# Altium schematic sheet Power.SchDoc
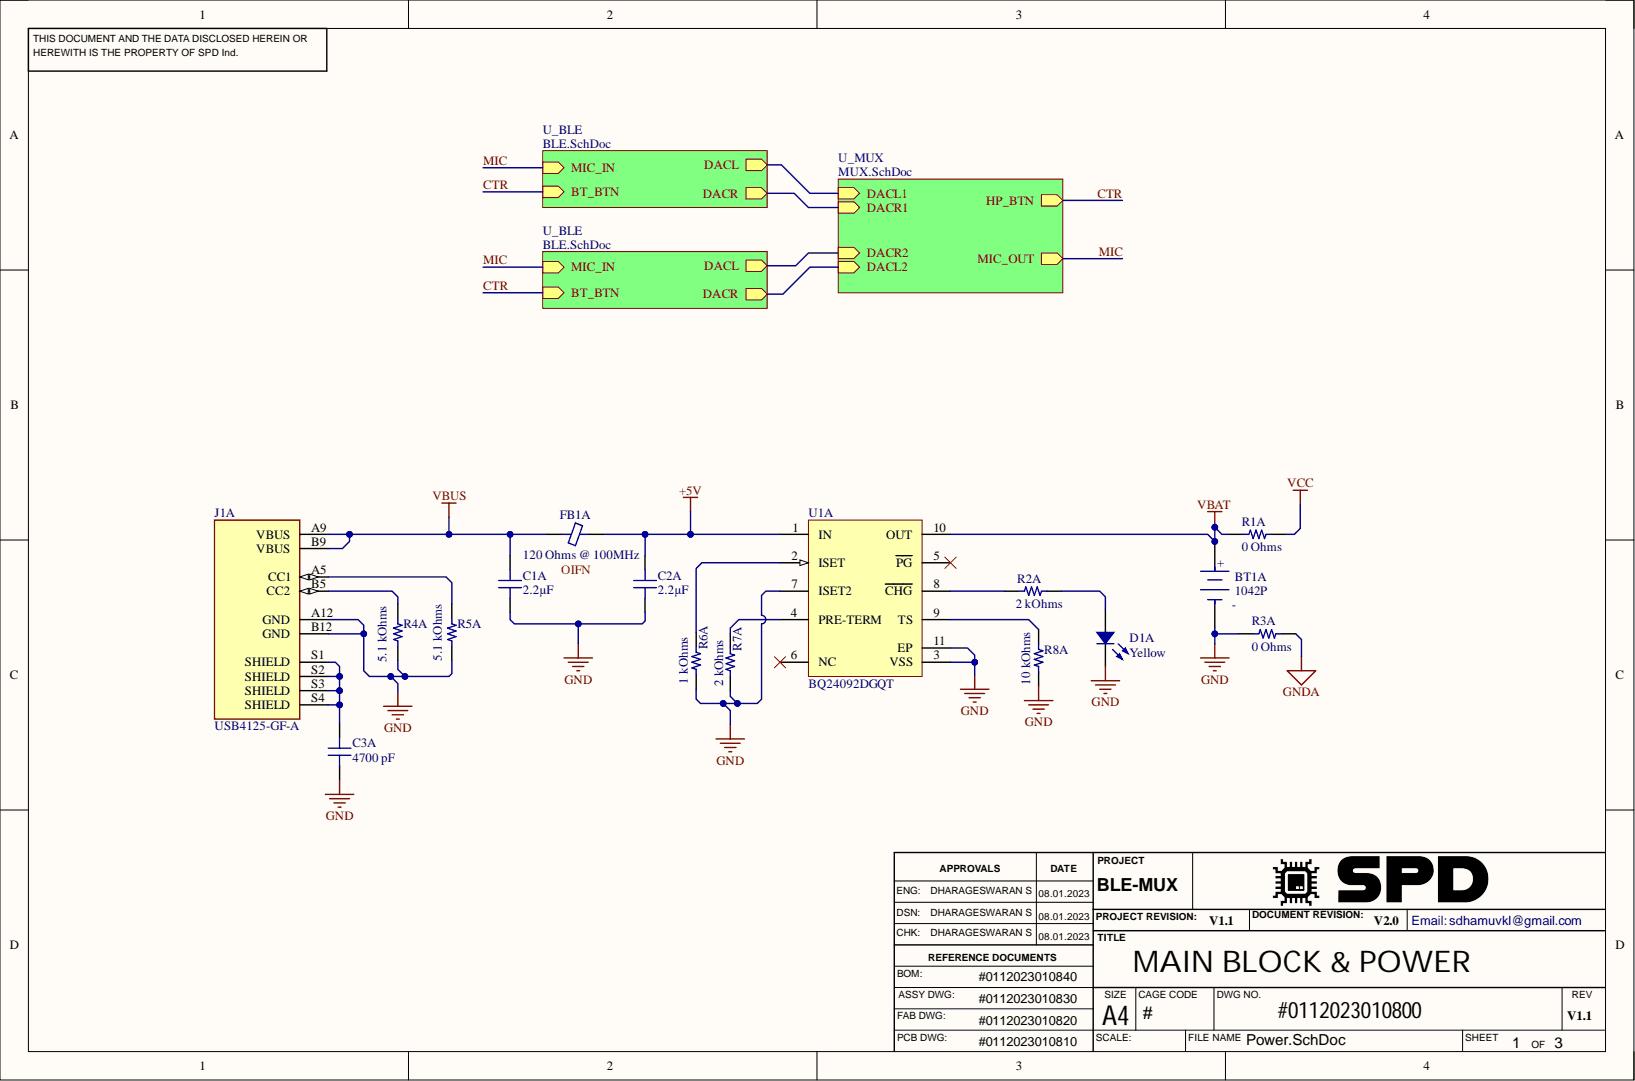
[diagram p0: Power.SchDoc]

## Parts
BT1A  1042P  [FP-1042P-MFG]
C1A  2.2µF  [CAP 0402_1005]
C2A  2.2µF  [CAP 0402_1005]
C3A  4700 pF  [CAP 0402_1005]
D1A  Yellow  [LED 0402_1005 YELLOW]
FB1A  120 Ohms @ 100MHz  [FER 0201_0603]
J1A  USB4125-GF-A  [USB4125-GF-A]
R1A  0 Ohms  [RES 0603_1608]
R2A  2 kOhms  [RES 0402_1005]
R3A  0 Ohms  [RES 0603_1608]
R4A  5.1 kOhms  [RES 0402_1005]
R5A  5.1 kOhms  [RES 0402_1005]
R6A  1 kOhms  [RES 0402_1005]
R7A  2 kOhms  [RES 0402_1005]
R8A  10 kOhms  [RES 0402_1005]
U1A  BQ24092DGQT  [DGQ0010D_L]

## Nets
+5V: C2A.2 FB1A.2 U1A.1(IN)
GND: BT1A.1 C1A.1 C2A.1 C3A.1 D1A.2 J1A.A12(GND) J1A.B12(GND) R3A.1 R4A.1 R5A.1 R6A.1 R7A.1 R8A.1 U1A.3(VSS) U1A.7(ISET2) U1A.11(EP)
GNDA: R3A.2
VBAT: BT1A.2 R1A.1 U1A.10(OUT)
VBUS: C1A.2 FB1A.1 J1A.A9(VBUS) J1A.B9(VBUS)
VCC: R1A.2
NetC3A_2: C3A.2 J1A.S1(SHIELD) J1A.S2(SHIELD) J1A.S3(SHIELD) J1A.S4(SHIELD)
NetD1A_1: D1A.1 R2A.1
NetJ1A_A5: J1A.A5(CC1) R5A.2
NetJ1A_B5: J1A.B5(CC2) R4A.2
NetR2A_2: R2A.2 U1A.8(CHG)
NetR6A_2: R6A.2 U1A.2(ISET)
NetR7A_2: R7A.2 U1A.4(PRE-TERM)
NetR8A_2: R8A.2 U1A.9(TS)
NetU1A_5: U1A.5(PG)
NetU1A_6: U1A.6(NC)
CTR:
DACL:
DACL2:
DACR:
MIC:

## Library data of the parts
BT1A = CMP-19636-000019-1: BATTERY HOLDER 18650 SMD TAB; Number of Cells: 1; Component Kind: Standard; Lead Free: Lead Free; Library Name: Altium Content Vault; Max Operating Temperature: 145°C; Min Operating Temperature: -50°C; Mount: Surface Mount; Package Quantity: 200; Packaging: Tray; Termination: SMD/SMT
C1A C2A = 0402ZD225KAT2A: CAP CER 2.2UF 10V X5R 0402; Manufacturer: AVX Corporation; Database Table Name: altium.Capacitors-Ceramic-0402; id: 2335; Tolerance: ±10%; Applications: General Purpose; Capacitance: 2.2µF; Component Kind: Standard; ComponentLink1Description: Datasheet; ComponentLink1URL: http://datasheets.avx.com/cx5r.pdf; ComponentLink2Description: DigiKey Link; ComponentLink2URL: http://digikey.com/product-detail/en/avx-corporation/0402ZD225KAT2A/478-10072-1-ND/6564294; Device Package: 0402 (1005 Metric); LastUpdated: 2022-02-08T12:26:45.707; Lifecycle Status: Active; Minimum Order: 1; Mounting Type: Surface Mount, MLCC; Operating Temperature: -55°C ~ 85°C; Package / Case: 0402 (1005 Metric); Packaging: TapeAndReel; Part Number: 0402ZD225KAT2A; Part Status: Active; PartId: 9346; Price: 0.09; Series: -; Size / Dimension: 0.039" L x 0.020" W (1.00mm x 0.50mm); Supplier 1: DigiKey; Supplier Part Number 1: 478-10072-1-ND; Temperature Coefficient: X5R; Thickness (Max): 0.022" (0.56mm); Value: 2.2µF; Voltage - Rated: 10V; Library Name: Postgres Altium Library - altium_library.DbLib
C3A = C0402C472K4RECAUTO: CAP CER 0402 4.7NF 16V X7R 10%; Manufacturer: KEMET; Database Table Name: altium.Capacitors-Ceramic-0402; id: 3032; Applications: Automotive, ESD Protection; Capacitance: 4700 pF; Component Kind: Standard; ComponentLink1Description: Datasheet; ComponentLink1URL: https://api.kemet.com/component-edge/download/datasheet/C0402C472K4RECAUTO.pdf; ComponentLink2Description: DigiKey Link; ComponentLink2URL: https://www.digikey.com/en/products/detail/kemet/C0402C472K4RECAUTO/8640469; Device Package: 0402 (1005 Metric); LastUpdated: 2023-01-03T20:03:46.940; Lifecycle Status: Active; Minimum Order: 1; Mounting Type: Surface Mount, MLCC; Operating Temperature: -55°C ~ 125°C; Package / Case: 0402 (1005 Metric); Packaging: TapeAndReel; Part Number: C0402C472K4RECAUTO; Part Status: Active; PartId: 12122; Price: 0.01; Ratings: AEC-Q200; Series: ESD SMD Auto X7R; Size / Dimension: 0.039" L x 0.020" W (1.00mm x 0.50mm); Supplier 1: DigiKey; Supplier Part Number 1: 399-17875-1-ND; Temperature Coefficient: X7R; Thickness (Max): 0.022" (0.55mm); Tolerance: ±10%; Value: 4700 pF; Voltage - Rated: 16V; Library Name: Postgres Altium Library - altium_library.DbLib
D1A = XZMYK68W-2: LED YELLOW CLEAR CHIP SMD; Manufacturer: SunLED; Configuration: Standard; Current - Test: 20mA; Database Table Name: altium.LED-Indication; id: 167; Lens Color: Colorless; Lens Size: 0.80mm x 0.50mm; Lens Style: Rectangle with Flat Top; Millicandela Rating: 148mcd; Viewing Angle: 120°; Voltage - Forward (Vf) (Typ): 2V; Wavelength - Dominant: 590nm; Color: Yellow; Component Kind: Standard; ComponentLink1Description: Datasheet; ComponentLink1URL: https://www.sunledusa.com/products/spec/XZMYK68W-2.pdf; ComponentLink2Description: DigiKey Link; ComponentLink2URL: https://www.digikey.com/en/products/detail/sunled/XZMYK68W-2/4745801; Device Package: 0402 (1005 Metric); Height (Max): 0.60mm; LastUpdated: 2023-01-02T20:57:30.307; Lens Transparency: Clear; Lifecycle Status: Active; Minimum Order: 1; Mounting Type: Surface Mount; Package / Case: 0402 (1005 Metric); Packaging: TapeAndReel; Part Number: XZMYK68W-2; Part Status: Active; PartId: 167; Price: 0.05; Series: -; Size / Dimension: 1.00mm L x 0.50mm W; Supplier 1: DigiKey; Supplier Device Package: Chip LED; Supplier Part Number 1: 1497-1198-1-ND; Value: Yellow; Wavelength - Peak: 590nm; Library Name: Postgres Altium Library - altium_library.DbLib
FB1A = BKP0603HM121-T: FERRITE BEAD 120 OHM 0201 1LN; Manufacturer: Taiyo Yuden; Current Rating (Max): 800mA; Database Table Name: altium.Filters-FerriteBeadsAndChips; DC Resistance (DCR) (Max): 180mOhm; Filter Type: Power Line; id: 127; Number Of Lines: 1; Component Kind: Standard; ComponentLink1Description: Datasheet; ComponentLink1URL: https://ds.yuden.co.jp/TYCOMPAS/ut/detail?pn=BKP0603HM121-T  &u=M; ComponentLink2Description: DigiKey Link; ComponentLink2URL: http://digikey.com/product-detail/en/taiyo-yuden/BKP0603HM121-T/587-3283-1-ND/4157927; Device Package: 0201 (0603 Metric); Height (Max): 0.013" (0.33mm); Impedance @ Frequency: 120 Ohms @ 100MHz; LastUpdated: 2023-01-02T20:50:51.660; Lifecycle Status: Active; Minimum Order: 1; Mounting Type: Surface Mount; Operating Temperature: -55°C ~ 85°C; Package / Case: 0201 (0603 Metric); Packaging: TapeAndReel; Part Number: BKP0603HM121-T; Part Status: Active; PartId: 127; Price: 0.03; Series: BK; Size / Dimension: 0.024" L x 0.012" W (0.60mm x 0.30mm); Supplier 1: DigiKey; Supplier Part Number 1: 587-3283-1-ND; Value: 120 Ohms @ 100MHz; Library Name: Postgres Altium Library - altium_library.DbLib
J1A = USB4125-GF-A: CONN RCPT TYPE C 6P SMD RA; Manufacturer: GCT; Connector Type: USB-C (USB TYPE-C); Current Rating (Amps): 3A; Database Table Name: altium.Connectors-USB-DVI-HDMI; Gender: Receptacle; id: 21; Mating Cycles: 20000; MP: USB4125-GF-A_REVA2; Number Of Contacts: 24 (6+18 Dummy); Number Of Ports: 1; Shielding: Shielded; Voltage - Rated: 20VDC; Availability: Unavailable; Component Kind: Standard; ComponentLink1Description: Datasheets; ComponentLink1URL: https://mm.digikey.com/Volume0/opasdata/d220001/medias/docus/5407/gct-usb-type-c-product-selection-guide-2023-v1.5_web.pdf; ComponentLink2Description: Datasheets; ComponentLink2URL: https://mm.digikey.com/Volume0/opasdata/d220001/medias/docus/5561/USB4125 - Product Spec.pdf; ComponentLink3Description: Datasheets; ComponentLink3URL: https://mm.digikey.com/Volume0/opasdata/d220001/medias/docus/5561/USB4125 - Product Drawing.pdf; ComponentLink4Description: Product Photos; ComponentLink4URL: https://mm.digikey.com/Volume0/opasdata/d220001/medias/images/2644/MFG_USB4125-GF-A.jpg; ComponentLink5Description: Video File; ComponentLink5URL: https://www.digikey.com/api/videos/videoplayer/smallplayer/6247993315001; ComponentLink6Description: Environmental Information; ComponentLink6URL: https://gct.co/files/info/gct_certificate_of_compliance.pdf; Device Package: Cut Tape (CT); Features: Power Only, No Data; Solder Retention Tab; Ingress Protection: -; LastUpdated: 2023-10-13T19:13:52.5975723; Lifecycle Status: Active; Minimum Order: 1; Mounting Feature: Horizontal; Mounting Type: Surface Mount, Right Angle; Through Hole; Operating Temperature: -30°C ~ 85°C; Package: None; Packaging: Cut Tape (CT); Part Number: USB4125-GF-A; Part Status: Active; Price: 0.67; Series: USB4125; Specifications: -; Supplier 1: DigiKey; Supplier Part Number 1: 2073-USB4125-GF-ACT-ND; Termination: Solder; Library Name: Postgres Altium Library - altium_library.DbLib
R1A R3A = RCC06030000Z0EA: RCC0603 0R0 ET1 E3; Manufacturer: Vishay Dale; Database Table Name: altium.Resistors-SurfaceMount-0603; id: 16538; Power (Watts): 0.2W, 1/5W; Tolerance: Jumper; Component Kind: Standard; ComponentLink1Description: Datasheet; ComponentLink1URL: https://www.vishay.com/docs/20066/rcce3.pdf; ComponentLink2Description: DigiKey Link; ComponentLink2URL: https://www.digikey.com/en/products/detail/vishay-dale/RCC06030000Z0EA/12084250; Composition: Thick Film; Device Package: 0603 (1608 Metric); Features: Automotive AEC-Q200; Height - Seated (Max): 0.020" (0.50mm); LastUpdated: 2023-01-02T20:27:57.037; Lifecycle Status: Active; Minimum Order: 1; Number Of Terminations: 2; Operating Temperature: -55°C ~ 155°C; Package / Case: 0603 (1608 Metric); Packaging: TapeAndReel; Part Number: RCC06030000Z0EA; Part Status: Active; PartId: 67023; Price: 0.01; Ratings: AEC-Q200; Resistance: 0 Ohms; Series: RCC e3; Size / Dimension: 0.061" L x 0.033" W (1.55mm x 0.85mm); Supplier 1: DigiKey; Supplier Device Package: 0603; Supplier Part Number 1: 541-RCC06030000Z0EACT-ND; Value: 0 Ohms; Library Name: Postgres Altium Library - altium_library.DbLib
R2A R7A = AC0402FR-7W2KL: RES 2K OHM 1% 1/8W 0402; Manufacturer: Yageo; Database Table Name: altium.Resistors-SurfaceMount-0402; id: 15390; Power (Watts): 0.125W, 1/8W; Temperature Coefficient: ±100ppm/°C; Component Kind: Standard; ComponentLink1Description: Datasheet; ComponentLink1URL: https://www.yageo.com/upload/media/product/productsearch/datasheet/rchip/PYu-AC_51_RoHS_L_10.pdf; ComponentLink2Description: DigiKey Link; ComponentLink2URL: https://www.digikey.com/en/products/detail/yageo/AC0402FR-7W2KL/16990150; Composition: Thick Film; Device Package: 0402 (1005 Metric); Features: Automotive AEC-Q200, Moisture Resistant; Height - Seated (Max): 0.015" (0.37mm); LastUpdated: 2023-10-29T19:24:41.980; Lifecycle Status: Active; Minimum Order: 1; Number Of Terminations: 2; Operating Temperature: -55°C ~ 155°C; Package / Case: 0402 (1005 Metric); Packaging: CutTape; Part Number: AC0402FR-7W2KL; PartId: 81829; Price: 0.01; Ratings: AEC-Q200; Resistance: 2 kOhms; Series: AC; Size / Dimension: 0.039" L x 0.020" W (1.00mm x 0.50mm); Supplier 1: DigiKey; Supplier Device Package: 0402; Supplier Part Number 1: 13-AC0402FR-7W2KLCT-ND; Tolerance: ±1%; Value: 2 kOhms; Library Name: Postgres Altium Library - altium_library.DbLib
R4A R5A = AC0402FR-075K1L: RES SMD 5.1K OHM 1% 1/16W 0402; Manufacturer: Yageo; Database Table Name: altium.Resistors-SurfaceMount-0402; id: 5028; Power (Watts): 0.063W, 1/16W; Temperature Coefficient: ±100ppm/°C; Component Kind: Standard; ComponentLink1Description: Datasheet; ComponentLink1URL: https://www.yageo.com/upload/media/product/productsearch/datasheet/rchip/PYu-AC_51_RoHS_L_7.pdf; ComponentLink2Description: DigiKey Link; ComponentLink2URL: https://www.digikey.com/en/products/detail/yageo/AC0402FR-075K1L/5895438; Composition: Thick Film; Device Package: 0402 (1005 Metric); Features: Automotive AEC-Q200, Moisture Resistant; Height - Seated (Max): 0.015" (0.37mm); LastUpdated: 2023-01-02T20:21:09.567; Lifecycle Status: Active; Minimum Order: 1; Number Of Terminations: 2; Operating Temperature: -55°C ~ 155°C; Package / Case: 0402 (1005 Metric); Packaging: CutTape; Part Number: AC0402FR-075K1L; Part Status: Active; PartId: 12111; Price: 0.01; Ratings: AEC-Q200; Resistance: 5.1 kOhms; Series: AC; Size / Dimension: 0.039" L x 0.020" W (1.00mm x 0.50mm); Supplier 1: DigiKey; Supplier Device Package: 0402; Supplier Part Number 1: YAG3503CT-ND; Tolerance: ±1%; Value: 5.1 kOhms; Library Name: Postgres Altium Library - altium_library.DbLib
R6A = AC0402FR-071KL: RES SMD 1K OHM 1% 1/16W 0402; Manufacturer: Yageo; Database Table Name: altium.Resistors-SurfaceMount-0402; id: 4988; Power (Watts): 0.063W, 1/16W; Temperature Coefficient: ±100ppm/°C; Component Kind: Standard; ComponentLink1Description: Datasheet; ComponentLink1URL: https://www.yageo.com/upload/media/product/productsearch/datasheet/rchip/PYu-AC_51_RoHS_L_7.pdf; ComponentLink2Description: DigiKey Link; ComponentLink2URL: https://www.digikey.com/en/products/detail/yageo/AC0402FR-071KL/2827801; Composition: Thick Film; Device Package: 0402 (1005 Metric); Features: Automotive AEC-Q200, Moisture Resistant; Height - Seated (Max): 0.015" (0.37mm); LastUpdated: 2023-01-02T20:21:09.907; Lifecycle Status: Active; Minimum Order: 1; Number Of Terminations: 2; Operating Temperature: -55°C ~ 155°C; Package / Case: 0402 (1005 Metric); Packaging: CutTape; Part Number: AC0402FR-071KL; Part Status: Active; PartId: 12037; Price: 0.01; Ratings: AEC-Q200; Resistance: 1 kOhms; Series: AC; Size / Dimension: 0.039" L x 0.020" W (1.00mm x 0.50mm); Supplier 1: DigiKey; Supplier Device Package: 0402; Supplier Part Number 1: 311-1KLBCT-ND; Tolerance: ±1%; Value: 1 kOhms; Library Name: Postgres Altium Library - altium_library.DbLib
R8A = AC0402FR-0710KL: RES SMD 10K OHM 1% 1/16W 0402; Manufacturer: Yageo; Database Table Name: altium.Resistors-SurfaceMount-0402; id: 4984; Power (Watts): 0.063W, 1/16W; Temperature Coefficient: ±100ppm/°C; Component Kind: Standard; ComponentLink1Description: Datasheet; ComponentLink1URL: https://www.yageo.com/upload/media/product/productsearch/datasheet/rchip/PYu-AC_51_RoHS_L_7.pdf; ComponentLink2Description: DigiKey Link; ComponentLink2URL: https://www.digikey.com/en/products/detail/yageo/AC0402FR-0710KL/5895030; Composition: Thick Film; Device Package: 0402 (1005 Metric); Features: Automotive AEC-Q200, Moisture Resistant; Height - Seated (Max): 0.015" (0.37mm); LastUpdated: 2023-01-02T20:21:09.957; Lifecycle Status: Active; Minimum Order: 1; Number Of Terminations: 2; Operating Temperature: -55°C ~ 155°C; Package / Case: 0402 (1005 Metric); Packaging: CutTape; Part Number: AC0402FR-0710KL; Part Status: Active; PartId: 12029; Price: 0.01; Ratings: AEC-Q200; Resistance: 10 kOhms; Series: AC; Size / Dimension: 0.039" L x 0.020" W (1.00mm x 0.50mm); Supplier 1: DigiKey; Supplier Device Package: 0402; Supplier Part Number 1: YAG3436CT-ND; Tolerance: ±1%; Value: 10 kOhms; Library Name: Postgres Altium Library - altium_library.DbLib
U1A = CMP-0321-00905-2: 1 A, Single-Input, Single-Cell Li-Ion Battery Charger with Operation Over JEITA and 10 k ohm NTC, 4.5 V, -40 to 150 degC, 10-pin MSOP-PowerPAD (DGQ), Green (RoHS & no Sb/Br); Manufacturer: Texas Instruments; Lead Acid: N; SubFamily: Battery Charge Management; Vin(Min)(V): 4.5; Code_JEDEC: MO-187-BA-T; Component Kind: Standard; ComponentLink1Description: Datasheet; ComponentLink1URL: http://www.ti.com/general/docs/lit/getliterature.tsp?genericPartNumber=BQ24092&fileType=pdf; DatasheetVersion: SLUS968C; Eco-Plan: Green (RoHS & no Sb/Br); Li-Ion: N; Li-Polymer: N; Library Name: Altium Content Vault; Mounting Technology: Surface Mount; NiCd: N; NiMH: N; Over Voltage Protection: N; PackageDescription: 10-Pin PowerPAD Plastic Small Outline 0.5 mm Pitch, Exposed Pad; PackageReference: DGQ0010D; PackageVersion: revF, Nov-2011; PartNumber: BQ24092DGQT
